# Altium schematic sheet Sheet1.SchDoc(Sheet1)
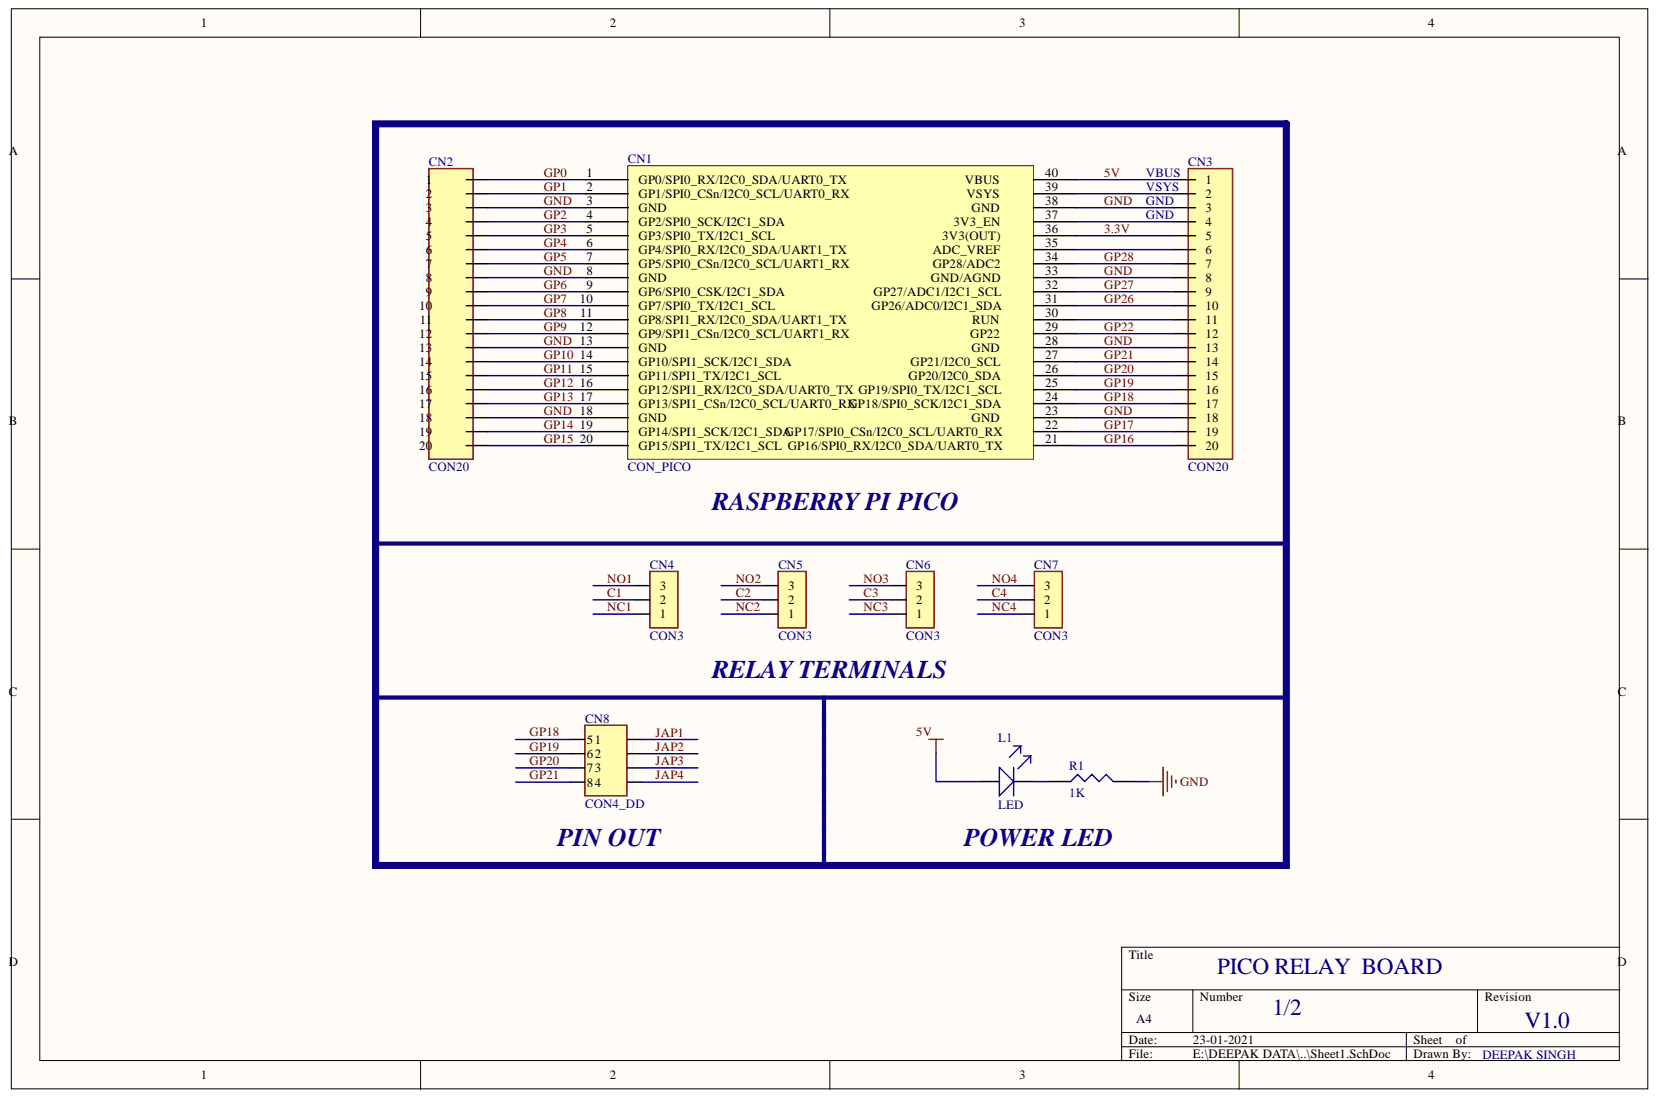
[diagram p0: Sheet1.SchDoc]

## Parts
CN1  CON_PICO  [CON40_PICO]
CN2  CON20  [CON20_2p5mm]
CN3  CON20  [CON20_2p5mm]
CN4  CON3  [CON3_5.0_R2]
CN5  CON3  [CON3_5.0_R2]
CN6  CON3  [CON3_5.0_R2]
CN7  CON3  [CON3_5.0_R2]
CN8  CON4_DD  [CON4_DD]
L1  LED  [LED_0603_neg]
R1  1K  [0603]

## Nets
3.3V: CN1.36 CN3.5
5V: CN1.40 CN3.1 L1.A
C1: CN4.2
C2: CN5.2
C3: CN6.2
C4: CN7.2
GND: CN1.3(GND) CN1.8(GND) CN1.13(GND) CN1.18(GND) CN1.23 CN1.28 CN1.33 CN1.38 CN2.3 CN2.8 CN2.13 CN2.18 CN3.3 CN3.8 CN3.13 CN3.18 R1.2
GP0: CN1.1(GP0/SPI0_RX/I2C0_SDA/UART0_TX) CN2.1
GP1: CN1.2(GP1/SPI0_CSn/I2C0_SCL/UART0_RX) CN2.2
GP2: CN1.4(GP2/SPI0_SCK/I2C1_SDA) CN2.4
GP3: CN1.5(GP3/SPI0_TX/I2C1_SCL) CN2.5
GP4: CN1.6(GP4/SPI0_RX/I2C0_SDA/UART1_TX) CN2.6
GP5: CN1.7(GP5/SPI0_CSn/I2C0_SCL/UART1_RX) CN2.7
GP6: CN1.9(GP6/SPI0_CSK/I2C1_SDA) CN2.9
GP7: CN1.10(GP7/SPI0_TX/I2C1_SCL) CN2.10
GP8: CN1.11(GP8/SPI1_RX/I2C0_SDA/UART1_TX) CN2.11
GP9: CN1.12(GP9/SPI1_CSn/I2C0_SCL/UART1_RX) CN2.12
GP10: CN1.14(GP10/SPI1_SCK/I2C1_SDA) CN2.14
GP11: CN1.15(GP11/SPI1_TX/I2C1_SCL) CN2.15
GP12: CN1.16 CN2.16
GP13: CN1.17(GP13/SPI1_CSn/I2C0_SCL/UART0_RX) CN2.17
GP14: CN1.19(GP14/SPI1_SCK/I2C1_SDA) CN2.19
GP15: CN1.20(GP15/SPI1_TX/I2C1_SCL) CN2.20
GP16: CN1.21 CN3.20
GP17: CN1.22 CN3.19
GP18: CN1.24 CN3.17 CN8.1
GP19: CN1.25 CN3.16 CN8.2
GP20: CN1.26 CN3.15 CN8.3
GP21: CN1.27 CN3.14 CN8.4
GP22: CN1.29 CN3.12
GP26: CN1.31 CN3.10
GP27: CN1.32 CN3.9
GP28: CN1.34 CN3.7
JAP1: CN8.5
JAP2: CN8.6
JAP3: CN8.7
JAP4: CN8.8
NC1: CN4.1
NC2: CN5.1
NC3: CN6.1
NC4: CN7.1
NO1: CN4.3
NO2: CN5.3
NO3: CN6.3
NO4: CN7.3
NetCN1_30: CN1.30 CN3.11
NetCN1_35: CN1.35 CN3.6
NetCN1_37: CN1.37 CN3.4
NetCN1_39: CN1.39 CN3.2
NetL1_K: L1.K R1.1

## Library data of the parts
CN1 = CON40_PICO: Component Kind: Standard; Library Name: dxp_pasl_lib.IntLib
R1 = RES: Component Kind: Standard; Library Name: dxp_pasl_lib.IntLib
CN2 CN3 = CON20: Component Kind: Standard; Library Name: dxp_pasl_lib.IntLib
CN4-CN7 = CON3: Component Kind: Standard; Library Name: dxp_pasl_lib.IntLib
CN8 = CON4_DD: Component Kind: Standard; Library Name: dxp_pasl_lib.IntLib
L1 = LED: Component Kind: Standard; Library Name: dxp_pasl_lib.IntLib
